# Altium schematic sheet DAC.SchDoc(DAC)
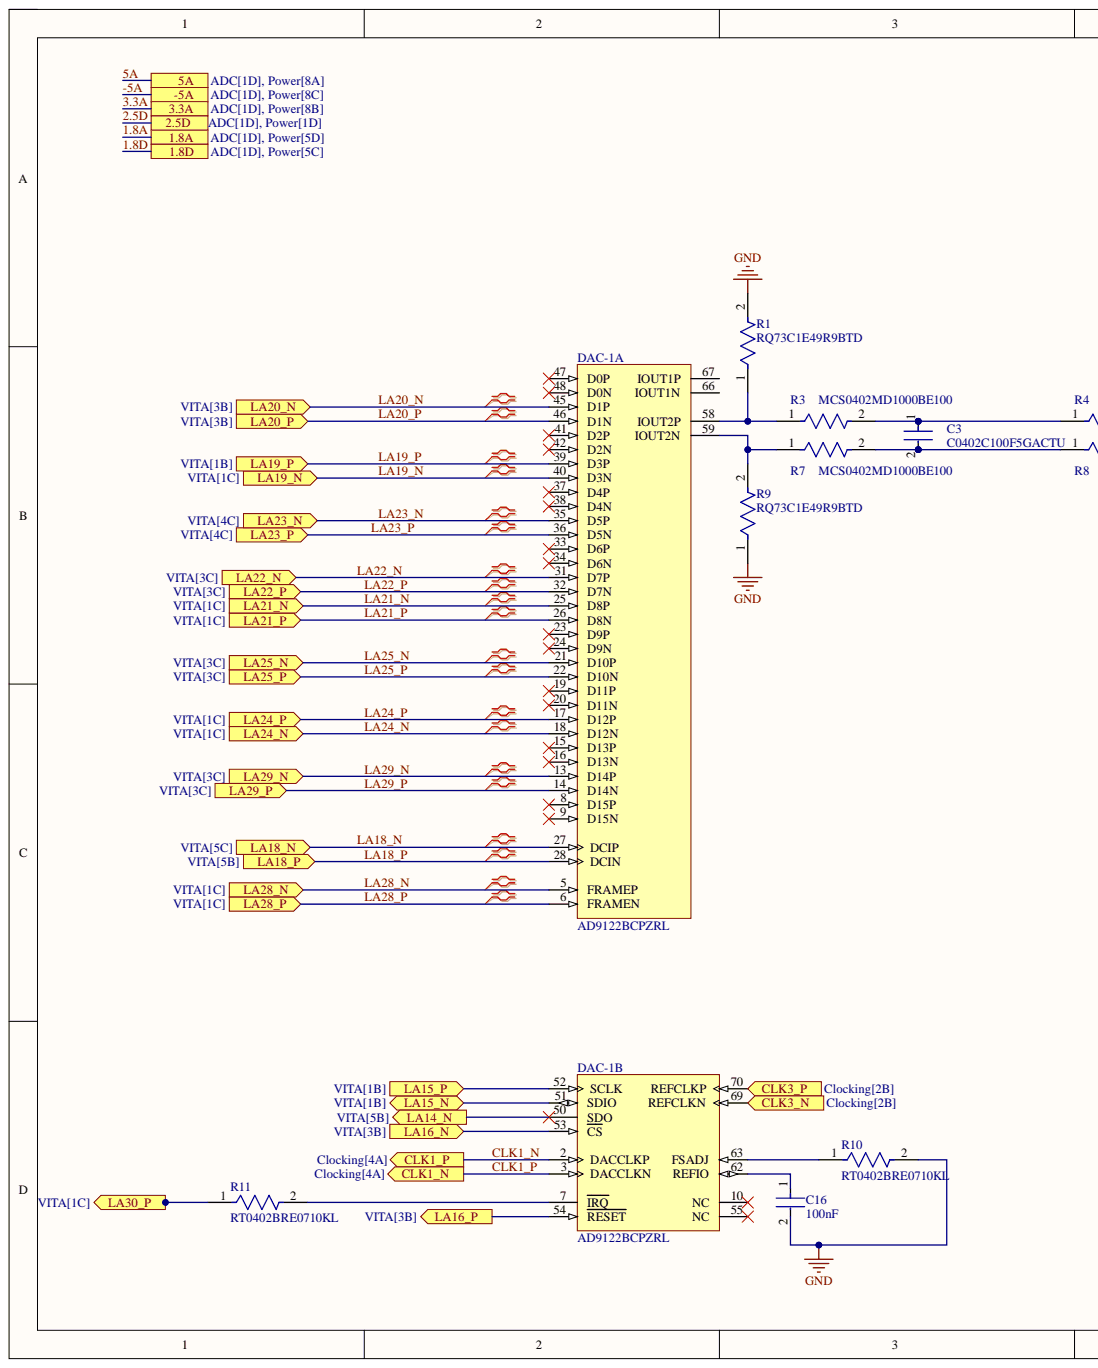
[diagram p0: DAC.SchDoc, left]
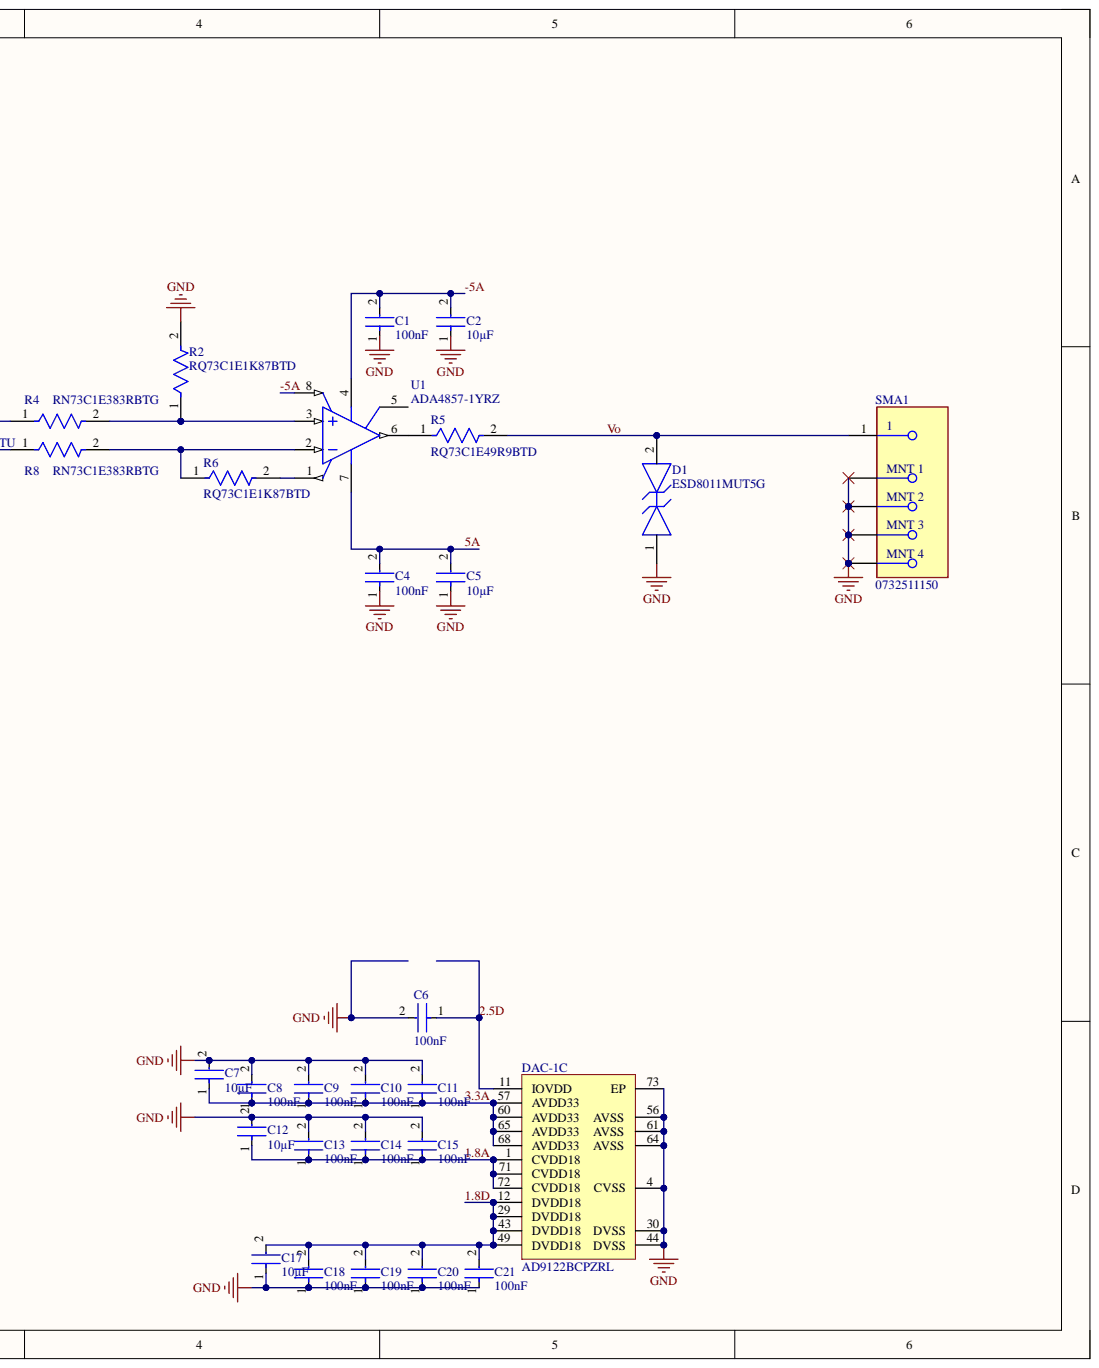
[diagram p1: DAC.SchDoc, right]

## Parts
C1  value 100nF  [FP-GRM033-0_03-MFG]  (hidden comment: GRM033Z71C104KE14D)
C2  value 10µF  [FP-GRM188-0_2-IPC_C]  (hidden comment: GRM188R61E106KA73D)
C3  C0402C100F5GACTU  [FP-C0402-BB-IPC_C]
C4  value 100nF  [FP-GRM033-0_03-MFG]  (hidden comment: GRM033Z71C104KE14D)
C5  value 10µF  [FP-GRM188-0_2-IPC_C]  (hidden comment: GRM188R61E106KA73D)
C6  value 100nF  [FP-GRM033-0_03-MFG]  (hidden comment: GRM033Z71C104KE14D)
C7  value 10µF  [FP-GRM188-0_2-IPC_C]  (hidden comment: GRM188R61E106KA73D)
C8  value 100nF  [FP-GRM033-0_03-MFG]  (hidden comment: GRM033Z71C104KE14D)
C9  value 100nF  [FP-GRM033-0_03-MFG]  (hidden comment: GRM033Z71C104KE14D)
C10  value 100nF  [FP-GRM033-0_03-MFG]  (hidden comment: GRM033Z71C104KE14D)
C11  value 100nF  [FP-GRM033-0_03-MFG]  (hidden comment: GRM033Z71C104KE14D)
C12  value 10µF  [FP-GRM188-0_2-IPC_C]  (hidden comment: GRM188R61E106KA73D)
C13  value 100nF  [FP-GRM033-0_03-MFG]  (hidden comment: GRM033Z71C104KE14D)
C14  value 100nF  [FP-GRM033-0_03-MFG]  (hidden comment: GRM033Z71C104KE14D)
C15  value 100nF  [FP-GRM033-0_03-MFG]  (hidden comment: GRM033Z71C104KE14D)
C16  value 100nF  [FP-GRM033-0_03-MFG]  (hidden comment: GRM033Z71C104KE14D)
C17  value 10µF  [FP-GRM188-0_2-IPC_C]  (hidden comment: GRM188R61E106KA73D)
C18  value 100nF  [FP-GRM033-0_03-MFG]  (hidden comment: GRM033Z71C104KE14D)
C19  value 100nF  [FP-GRM033-0_03-MFG]  (hidden comment: GRM033Z71C104KE14D)
C20  value 100nF  [FP-GRM033-0_03-MFG]  (hidden comment: GRM033Z71C104KE14D)
C21  value 100nF  [FP-GRM033-0_03-MFG]  (hidden comment: GRM033Z71C104KE14D)
D1  ESD8011MUT5G  [FP-152AF-MFG]
DAC-1  AD9122BCPZRL  [CP-72-7_M]  (parts A,B,C)
R1  RQ73C1E49R9BTD  [FP-RQ731E-IPC_C]
R2  RQ73C1E1K87BTD  [FP-RQ731E-IPC_C]
R3  MCS0402MD1000BE100  [FP-MCS0402-IPC_C]
R4  RN73C1E383RBTG  [FP-RN731E-IPC_C]
R5  RQ73C1E49R9BTD  [FP-RQ731E-IPC_B]
R6  RQ73C1E1K87BTD  [FP-RQ731E-IPC_C]
R7  MCS0402MD1000BE100  [FP-MCS0402-IPC_C]
R8  RN73C1E383RBTG  [FP-RN731E-IPC_C]
R9  RQ73C1E49R9BTD  [FP-RQ731E-IPC_C]
R10  RT0402BRE0710KL  [FP-RT0402-IPC_C]
R11  RT0402BRE0710KL  [FP-RT0402-IPC_C]
SMA1  0732511150  [FP-0732511150-MFG]
U1  ADA4857-1YRZ  [ADI-R-8_L]

## Ports
1.8A, 1.8D, 2.5D, 3.3A, 5A, -5A, CLK1_N, CLK1_P, CLK3_N, CLK3_P, LA14_N, LA15_N, LA15_P, LA16_N, LA16_P, LA18_N, LA18_P, LA19_N, LA19_P, LA20_N, LA20_P, LA21_N, LA21_P, LA22_N, LA22_P, LA23_N, LA23_P, LA24_N, LA24_P, LA25_N, LA25_P, LA28_N, LA28_P, LA29_N, LA29_P, LA30_P

## Nets
GND: C1.1 C2.1 C4.1 C5.1 C6.2 C7.2 C8.2 C9.2 C10.2 C11.2 C12.2 C13.2 C14.2 C15.2 C16.2 C17.1 C18.1 C19.1 C20.1 C21.1 D1.1 DAC-1.4(CVSS) DAC-1.30(DVSS) DAC-1.44(DVSS) DAC-1.56(AVSS) DAC-1.61(AVSS) DAC-1.64(AVSS) DAC-1.73(EP) R1.2 R2.2 R9.1 R10.2 SMA1.2 SMA1.3 SMA1.4 SMA1.5
1.8A: C12.1 C13.1 C14.1 C15.1 DAC-1.1(CVDD18) DAC-1.71(CVDD18) DAC-1.72(CVDD18) ; port 1.8A
1.8D: C17.2 C18.2 C19.2 C20.2 C21.2 DAC-1.12(DVDD18) DAC-1.29(DVDD18) DAC-1.43(DVDD18) DAC-1.49(DVDD18) ; port 1.8D
2.5D: C6.1 DAC-1.11(IOVDD) ; port 2.5D
3.3A: C7.1 C8.1 C9.1 C10.1 C11.1 DAC-1.57(AVDD33) DAC-1.60(AVDD33) DAC-1.65(AVDD33) DAC-1.68(AVDD33) ; port 3.3A
5A: C4.2 C5.2 U1.7 ; port 5A
-5A: C1.2 C2.2 U1.4 U1.8 ; port -5A
CLK1_N: DAC-1.2(DACCLKP) ; port CLK1_P
CLK1_P: DAC-1.3(DACCLKN) ; port CLK1_N
CLK3_N: DAC-1.69(REFCLKN) ; port CLK3_N
CLK3_P: DAC-1.70(REFCLKP) ; port CLK3_P
LA14_N: DAC-1.50(SDO) ; port LA14_N
LA15_N: DAC-1.51(SDIO) ; port LA15_N
LA15_P: DAC-1.52(SCLK) ; port LA15_P
LA16_N: DAC-1.53(CS) ; port LA16_N
LA16_P: DAC-1.54(RESET) ; port LA16_P
LA18_N: DAC-1.27(DCIP) ; port LA18_N
LA18_P: DAC-1.28(DCIN) ; port LA18_P
LA19_N: DAC-1.40(D3N) ; port LA19_N
LA19_P: DAC-1.39(D3P) ; port LA19_P
LA20_N: DAC-1.45(D1P) ; port LA20_N
LA20_P: DAC-1.46(D1N) ; port LA20_P
LA21_N: DAC-1.25(D8P) ; port LA21_N
LA21_P: DAC-1.26(D8N) ; port LA21_P
LA22_N: DAC-1.31(D7P) ; port LA22_N
LA22_P: DAC-1.32(D7N) ; port LA22_P
LA23_N: DAC-1.35(D5P) ; port LA23_N
LA23_P: DAC-1.36(D5N) ; port LA23_P
LA24_N: DAC-1.18(D12N) ; port LA24_N
LA24_P: DAC-1.17(D12P) ; port LA24_P
LA25_N: DAC-1.21(D10P) ; port LA25_N
LA25_P: DAC-1.22(D10N) ; port LA25_P
LA28_N: DAC-1.5(FRAMEP) ; port LA28_N
LA28_P: DAC-1.6(FRAMEN) ; port LA28_P
LA29_N: DAC-1.13(D14P) ; port LA29_N
LA29_P: DAC-1.14(D14N) ; port LA29_P
LA30_P: R11.1 ; port LA30_P
Vo: D1.2 R5.2 SMA1.1
NetC3_1: C3.1 R3.2 R4.1
NetC3_2: C3.2 R7.2 R8.1
NetC16_1: C16.1 DAC-1.62(REFIO)
NetDAC-1_7: DAC-1.7(IRQ) R11.2
NetDAC-1_58: DAC-1.58(IOUT2P) R1.1 R3.1
NetDAC-1_59: DAC-1.59(IOUT2N) R7.1 R9.2
NetDAC-1_63: DAC-1.63(FSADJ) R10.1
NetR2_1: R2.1 R4.2 U1.3
NetR5_1: R5.1 U1.6
NetR6_1: R6.1 R8.2 U1.2
NetR6_2: R6.2 U1.1

Not connected: DAC-1 8(D15P) 9(D15N) 10(NC) 15(D13P) 16(D13N) 19(D11P) 20(D11N) 23(D9P) 24(D9N) 33(D6P) 34(D6N) 37(D4P) 38(D4N) 41(D2P) 42(D2N) 47(D0P) 48(D0N) 55(NC) 66(IOUT1N) 67(IOUT1P); U1 5

## Library data of the parts
C1 C4 C6 C8-C11 C13-C16 C18-C21 = CMP-001-00085-1: 16V 100nF X7R ±10% 0201 Multilayer Ceramic Capacitors MLCC - SMD/SMT ROHS; Case/Package: 0201; Component Kind: Standard; Max Operating Temperature: 125°C; Min Operating Temperature: -55°C; Mounting Technology: Surface Mount; Tolerance: 10%; Voltage Rating: 16V; Library Name: Alex Clunan
C2 C5 C7 C12 C17 = CMP-001-00086-1: 25V 10uF X5R ±10% 0603 Multilayer Ceramic Capacitors MLCC - SMD/SMT ROHS; Case/Package: 0603; Component Kind: Standard; Max Operating Temperature: 85°C; Min Operating Temperature: -55°C; Mounting Technology: Surface Mount; Tolerance: 10%; Voltage Rating: 25V; Library Name: Alex Clunan
C3 = CMP-03020-013070-1: CAP CER 10PF 50V C0G/NP0 0402; Manufacturer: Kemet; Material: Ceramic; Temperature Characteristic: C0G; Temperature Coefficient: 15%; Tolerance: 0.01; Automotive: No; Capacitance: 10 pF; Category: Cap; Component Kind: Standard; ComponentLink2Description: Datasheet; ComponentLink2URL: https://content.kemet.com/datasheets/KEM_C1002_X7R_SMD.pdf; Device Class L1: Passive Components; Device Class L2: Capacitors; Device Class L3: Ceramic Capacitors; Digikey Description: CAP CER 10PF 50V C0G/NP0 0402; Height: 0.55mm; Ipc Land Pattern Name: CAPC10050X50; Lead Free: Yes; Library Name: Altium Content Vault; Manufacturer Part Number: C0402C100F5GACTU; Mouser Description: Cap Ceramic 10pF 50V C0G 1% SMD 0402 125°C Punched Paper T/R; Octopart: https://octopart.com/c0402c100f5gactu-kemet-20494328; Package: C0402-BB; RoHS: TRUE; Temperature: -55°C to +125°C; Temperature Range High: +125°C; Temperature Range Low: -55°C; Value: 10 pF; Voltage Rating: 50 V
D1 = CMP-00278-53637930-1: ESD8011MUT5G ESD8011MUT5G, Bi-Directional ESD Protection Diode, 2-Pin X3DFN; Manufacturer Lifecycle Status: ACTIVE; Breakdown Voltage: 6.5V; Capacitance: 100fF; Clamping Voltage: 17.2V; Direction: Bidirectional; Element Configuration: Common Cathode; ESD Protection: Yes; Max Junction Temperature (Tj): 125°C; Max Reverse Leakage Current: 1µA; Min Breakdown Voltage: 7.3V; Number of Bidirectional Channels: 1; Operating Supply Voltage: 5.5V; Peak Pulse Current: 3.6A; Peak Pulse Power: 34W; Power Line Protection: No; Series Resistance: 1Ω; Test Current: 1mA; Case/Package: DFN; Component Kind: Standard; Composition: Zener; Contact Plating: Gold; Height: 280µm; Lead Free: Lead Free; Length: 660µm; Library Name: Altium Content Vault; Lifecycle Status: Production; Max Operating Temperature: 125°C; Min Operating Temperature: -55°C; Mount: Surface Mount; NexarPartId: 53637930; Number of Channels: 1; Number of Pins: 2; Packaging: Cut Tape; REACH SVHC: No SVHC; Reverse Standoff Voltage: 5.5V; RoHS: Compliant; Schedule B: 8536300000; Width: 360µm
DAC-1 = CMP-0318-00624-2: Dual 16-Bit TxDAC, 1230 Msps, -40 to +85 degC, CP-72-7, Pb-Free, Reel; Generic Part Number: AD9122; Manufacturer: Analog Devices; # DAC Outputs: 2; Max V+: 3.47V; Op Pwr Diss: 1.1W; Resolution (Bits): 16; Single Supply: No; Code_JEDEC: MO-220-VNND-4; Component Kind: Standard; ComponentLink1Description: Manufacturer URL; ComponentLink1URL: http://www.analog.com/en/index.html; ComponentLink2Description: Datasheet; ComponentLink2URL: http://www.analog.com/static/imported-files/data_sheets/AD9122.pdf; ComponentLink3Description: Package Specification; ComponentLink3URL: http://www.analog.com/static/imported-files/packages/PKG_PDF/LFCSP(CP)/CP_72_7.pdf; ComponentLink4Description: Product URL; ComponentLink4URL: http://www.analog.com/en/digital-to-analog-converters/da-converters/ad9122/products/product.html; DatasheetVersion: Rev.B; Library Name: Altium Content Vault; Mounting Technology: Surface Mount; PackageDescription: 72-Lead Lead Frame Chip Scale Package [LFCSP_VQ] 10 x 10 mm Body, Very Thin Quad; PackageReference: CP-72-7; PackageVersion: 052809-A; Packing: Reel; PartNumber: AD9122BCPZRL; RevisionNote: Component URL fixed; RoHS: Y
R1 R5 R9 = CMP-03211-011281-1: RQ73 0402 49.9Ω 0.0625W 10ppm/°C 0.1% 50V; Component Kind: Standard; Library Name: Altium Content Vault
R2 R6 = CMP-03211-006964-1: RQ73 0402 1.87KΩ 0.0625W 10ppm/°C 0.1% 50V; Manufacturer: TE; Automotive Grade: Grade 0; Automotive: Yes; Category: Res; Component Kind: Standard; ComponentLink2Description: Datasheet; ComponentLink2URL: https://www.te.com/commerce/DocumentDelivery/DDEController?Action=showdoc&DocId=Data+Sheet%7F1773270-2%7FA%7Fpdf%7FEnglish%7FENG_DS_1773270-2_A.pdf%7F4-2176362-374; Composition: Thin Film; Device Class L1: Passive Components; Device Class L2: Resistors; Device Class L3: Chip SMD Resistors; Height: 0.4mm; Ipc Land Pattern Name: RESC10050X30; Lead Free: Yes; Library Name: Altium Content Vault; Manufacturer Part Number: RQ73C1E1K87BTD; Octopart: https://octopart.com/6-2176363-2-te+connectivity-93905072; Package: RQ731E; Power Rating: 0.0625W; Resistance: 1.87KΩ; RoHS: TRUE; Series: RQ73; Temperature: -55°C to +155°C; Temperature Coefficient: 10ppm/°C; Temperature Range High: +155°C; Temperature Range Low: -55°C; Tolerance: 0.1%; Value: 1.87KΩ; Voltage Rating: 50V
R3 R7 = CMP-02407-000612-1: RES SMD 100 OHM 1% 1/10W 0402; Power Rating: 63mW; Resistance: 100R; Temperature Coefficient: 25ppm/°C; Tolerance: 0.1%; Voltage Rating: 50V; Case Code (Imperial): 0402; Case Code (Metric): 1005; Case/Package: 0402; Component Kind: Standard; Composition: Thin Film; Contact Plating: Tin; Height: 0.32mm; Length: 1mm; Library Name: Altium Content Vault; Max Operating Temperature: 155°C; Min Operating Temperature: -55°C; Packaging: Tape and Reel; Termination: SMD/SMT; Weight: 2.1E-05oz; Width: 0.5mm
R4 R8 = CMP-03211-005182-1: Res Thin Film 0402 383Ω 0.1% 1/16W 10ppm/°C Molded SMD; Manufacturer: TE Connectivity; Power Rating: 0.063W; Voltage Rating: 25V; Automotive: No; Category: Res; Component Kind: Standard; ComponentLink2Description: Datasheet; ComponentLink2URL: https://www.te.com/commerce/DocumentDelivery/DDEController?Action=showdoc&DocId=Data+Sheet%7F1773270%7FP%7Fpdf%7FEnglish%7FENG_DS_1773270_P.pdf%7F1-1879132-6; Composition: Thin Film; Device Class L1: Passive Components; Device Class L2: Resistors; Device Class L3: Chip SMD Resistors; Height: 0.35mm; Ipc Land Pattern Name: RESC10050X30; Lead Free: Yes; Library Name: Altium Content Vault; Manufacturer Part Number: RN73C1E383RBTG; Octopart: https://octopart.com/rn73c1e383rbtg-te+connectivity-21703779; octopart_url: https://octopart.com/rn73c1e383rbtg-te+connectivity-21703779; Package: RN731E; packaging: 250 cut tape length; Resistance: 383Ω; RoHS: TRUE; Series: RN73; Temperature: -55°C to +155°C; Temperature Coefficient: 10ppm/°C; Temperature Range High: +155°C; Temperature Range Low: -55°C; Tolerance: 0.1%; Value: 383Ω
R10 R11 = CMP-2002-02973-2: RES SMD 10K OHM 0.1% 1/16W 0402; Manufacturer: Yageo; Power Rating: 0.063W; Resistance: 10KΩ; Temperature Coefficient: 50 ppm/°C; Tolerance: 0.001; Value: 10KΩ; Voltage Rating: 50V; Automotive: No; Category: Res; Component Kind: Standard; ComponentLink2Description: Datasheet; ComponentLink2URL: http://www.yageo.com/documents/recent/PYu-RT_1-to-0.01_RoHS_L_9.pdf; Composition: Thin Film; Device Class L1: Passive Components; Device Class L2: Resistors; Device Class L3: Chip SMD Resistors; Digikey Description: RES SMD 10K OHM 0.1% 1/16W 0402; Footprint Url: http://www.yageo.com/exep/pages/download/literatures/PYu-R_Mount_10.pdf; Height: 0.35mm; Ipc Land Pattern Name: RESC10050X30; Lead Free: Yes; Library Name: Altium Content Vault; Manufacturer Part Number: RT0402BRE0710KL; Mouser Description: Res Thin Film 0402 10K Ohm 0.1% 1/16W ±50ppm/°C Molded SMD Paper T/R; Octopart: https://octopart.com/rt0402bre0710kl-yageo-1416557; Package: RT0402; RoHS: TRUE; Series: RT; Temperature: -55°C to +155°C; Temperature Range High: +155°C; Temperature Range Low: -55°C
SMA1 = CMP-04764-000001-1: CONN SMA RCPT STR 50OHM EDGE MNT; Manufacturer: Molex Inc; Conn Orientation: Horizontal; Dielectric Material: PTFE; Frequency: 18GHz; Impedance: 50Ω; Is Female: yes; Number Of Contacts: 1; Shielding: true; Voltage Rating: 500V; Automotive: No; Category: Conn; Component Kind: Standard; ComponentLink2Description: Datasheet; ComponentLink2URL: https://www.molex.com/webdocs/datasheets/pdf/en-us/0732511150_RF_COAX_CONNECTORS.pdf; Contact Material: Gold,Nickel; Device Class L1: Connectors; Device Class L2: RF / Coaxial Connectors; Device Class L3: unset; Digikey Description: CONN SMA RCPT STR 50OHM EDGE MNT; Footprint Url: https://www.molex.com/pdm_docs/sd/732511150_sd.pdf; Lead Free: Yes; Library Name: Altium Content Vault; Manufacturer Part Number: 0732511150; Mouser Description: RF Connectors / Coaxial Connectors SMA ST PCB-JCK STD EMT; Octopart: https://octopart.com/73251-1150-molex-777272; Package: 0; RoHS: TRUE; Temperature: -65°C to +165°C; Temperature Range High: +165°C; Temperature Range Low: -65°C
U1 = CMP-0384-00877-1: Single Channel Low Power Operational Amplifier, 850 MHz BW, 2.8 KV/us SR, 8-pin SOIC (R-8), Tube; Manufacturer: Analog Devices; Amplifiers Per Package: 1; Input Bias Current: 2uA; Input Offset Voltage: 2mV; Iq/Amp: 5.5mA; Small Signal Bandwidth: 850MHz; V Span Max: 10.5V; V Span Min: 4.5V; Code_JEDEC: MS-012-AA; Component Kind: Standard; ComponentLink1Description: Manufacturer URL; ComponentLink1URL: http://www.analog.com/en/index.html; ComponentLink2Description: Datasheet; ComponentLink2URL: http://www.analog.com/static/imported-files/data_sheets/ADA4857-1_4857-2.pdf; ComponentLink3Description: Package Specification; ComponentLink3URL: http://www.analog.com/static/imported-files/packages/PKG_PDF/SOIC_Narrow%20(R)/R_8.pdf; DatasheetVersion: Rev. B; Generic Part Number: ADA4857-1; Library Name: Altium Content Vault; Mounting Technology: Surface Mount; PackageDescription: 8-Pin Standard Small Outline Package, Body 3.9 x 4.9 mm, Pitch 1.27 mm; PackageReference: R-8; PackageVersion: 060506-A; PartNumber: ADA4857-1YRZ; Slew Rate: 2.8KV/us
